# Altium schematic sheet DRV8837CDSGR.SchDoc
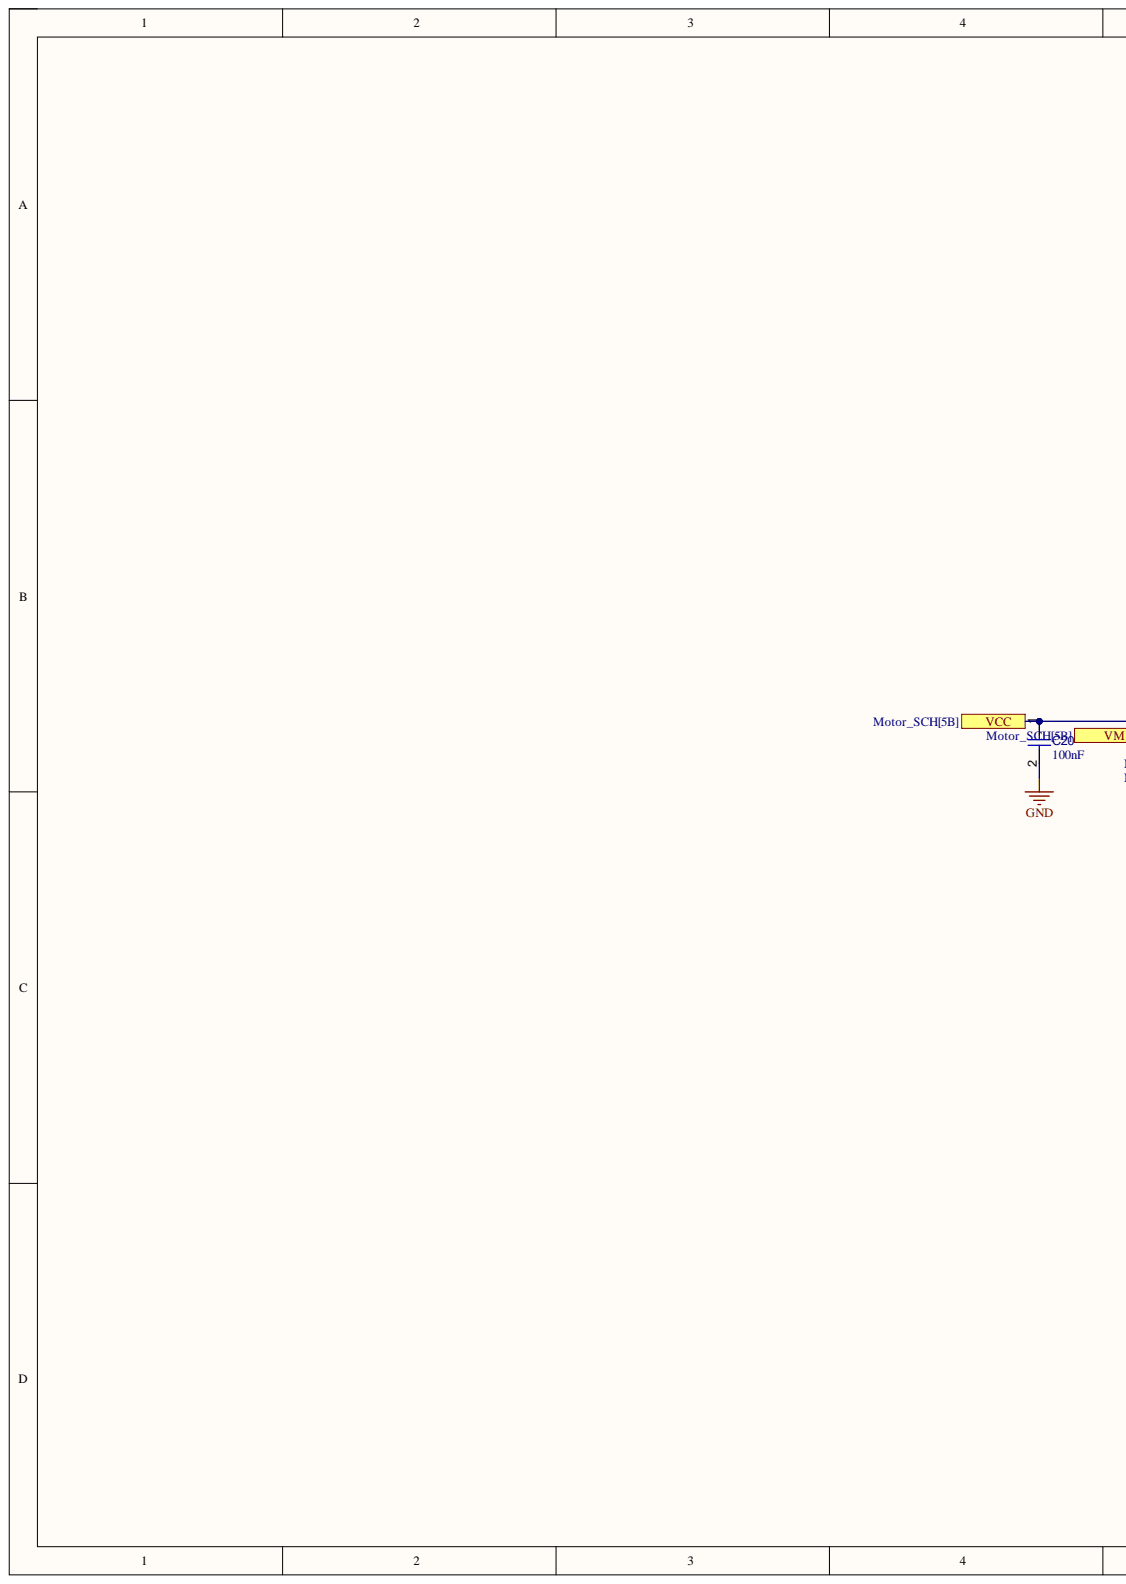
[diagram p0: DRV8837CDSGR.SchDoc, left]
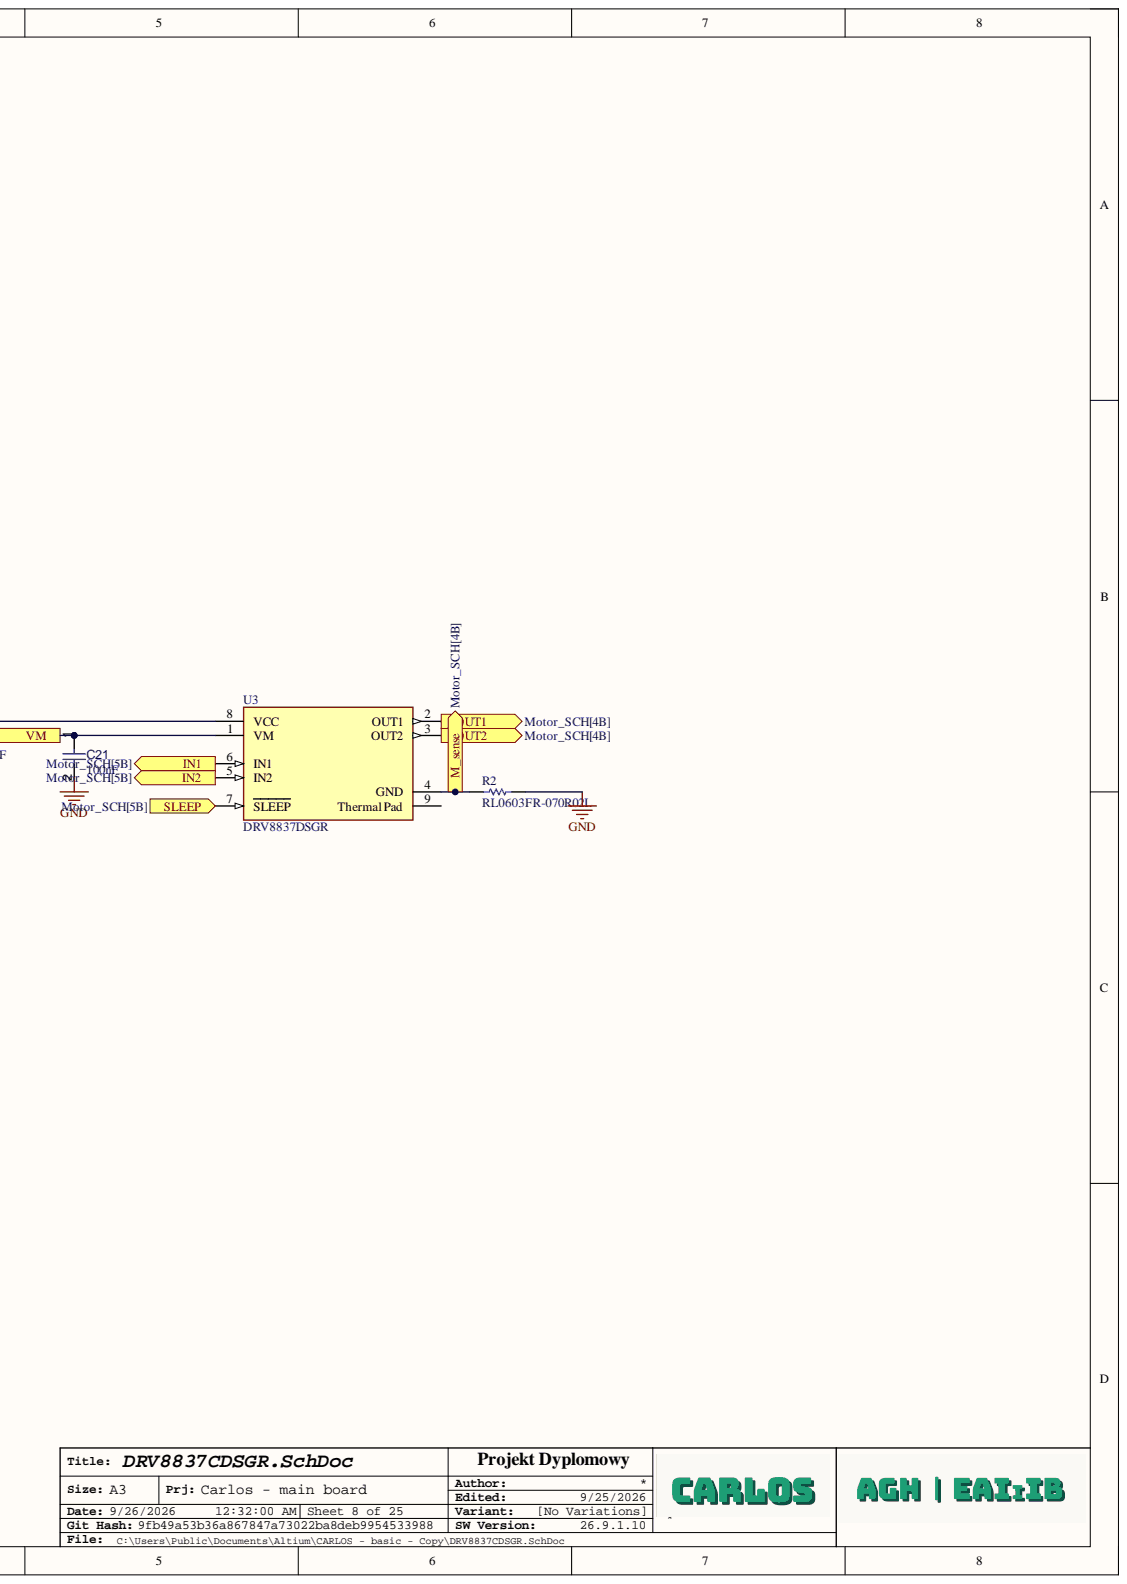
[diagram p1: DRV8837CDSGR.SchDoc, right]

## Parts
C20  value 100nF  [CAPC1608X90X40NL10T20]  (hidden comment: Capacitor 100 nF +/-10% 50 V 0603)
C21  value 100nF  [CAPC1608X90X40NL10T20]  (hidden comment: Capacitor 100 nF +/-10% 50 V 0603)
R2  RL0603FR-070R02L  [RESC1608X55X25ML10T15]
U3  DRV8837DSGR  [FP-DSG0008A-MFG]

## Ports
IN1, IN2, M_sense, OUT1, OUT2, SLEEP, VCC, VM

## Nets
GND: C20.2 C21.2 R2.2
IN1: U3.6(IN1) ; port IN1
IN2: U3.5(IN2) ; port IN2
M_sense: R2.1 U3.4(GND) ; port M_sense
OUT1: U3.2(OUT1) ; port OUT1
OUT2: U3.3(OUT2) ; port OUT2
SLEEP: U3.7(SLEEP) ; port SLEEP
VCC: C20.1 U3.8(VCC) ; port VCC
VM: C21.1 U3.1(VM) ; port VM

Not connected: U3 9(Thermal_Pad)

## Library data of the parts
C20 C21 = CMP-001-00017-6: Chip Capacitor, 100 nF, +/- 10%, 50 V, 0603 (1608 Metric); Capacitor Type: Ceramic; Voltage Rating: 50V; Case/Package: 0603; Component Kind: Standard; Max Operating Temperature: 125°C; Min Operating Temperature: -55°C; Mounting Technology: SM; Pins: 2; RoHS Compliant: True; Tolerance: 10%; Library Name: Jakub Sikora
R2 = CMP-2000-04331-1: Manufacturer: Yageo; Component Kind: Standard; Library Name: Altium Content Vault; Part Number: RL0603FR-070R02L
U3 = CMP-04915-000004-1: IC MOTOR DRIVER PAR 8WSON; Manufacturer: Texas Instruments; Max Junction Temp: +150°C; Max Supply Voltage: 7V; Min Supply Voltage: 1.8V; Motor Type: Brushed; Nominal Supply Current: 300-700uA; Number Of Outputs: 2; Output Current: 1.8A; Output Voltage: 0-11V; Standoff Height: 0mm; Switching Frequency: 0-250kHz; Ambient Temperature Range High: +85°C; Ambient Temperature Range Low: -40°C; Automotive: No; Category: IC; Component Kind: Standard; ComponentLink2Description: Datasheet; ComponentLink2URL: http://www.ti.com/lit/ds/symlink/drv8837.pdf; Device Class L1: Integrated Circuits (ICs); Device Class L2: Power Management ICs; Device Class L3: Motor Drivers; Digikey Description: IC MOTOR DRIVER PAR 8WSON; Height: 0.8mm; Lead Free: Yes; Library Name: Altium Content Vault; Manufacturer Part Number: DRV8837DSGR; Mouser Description: Motor / Motion / Ignition Controllers & Drivers 1.8A Low Vltg Brush DC Motor Driver; Octopart: https://octopart.com/drv8837dsgr-texas+instruments-23786726; Package: DSG0008A; RoHS: TRUE; Temperature: -40°C to +85°C; Temperature Range High: +85°C; Temperature Range Low: -40°C
